# Altium schematic sheet JGL1905_Xyloni_FTDI_V1.06.SchDoc
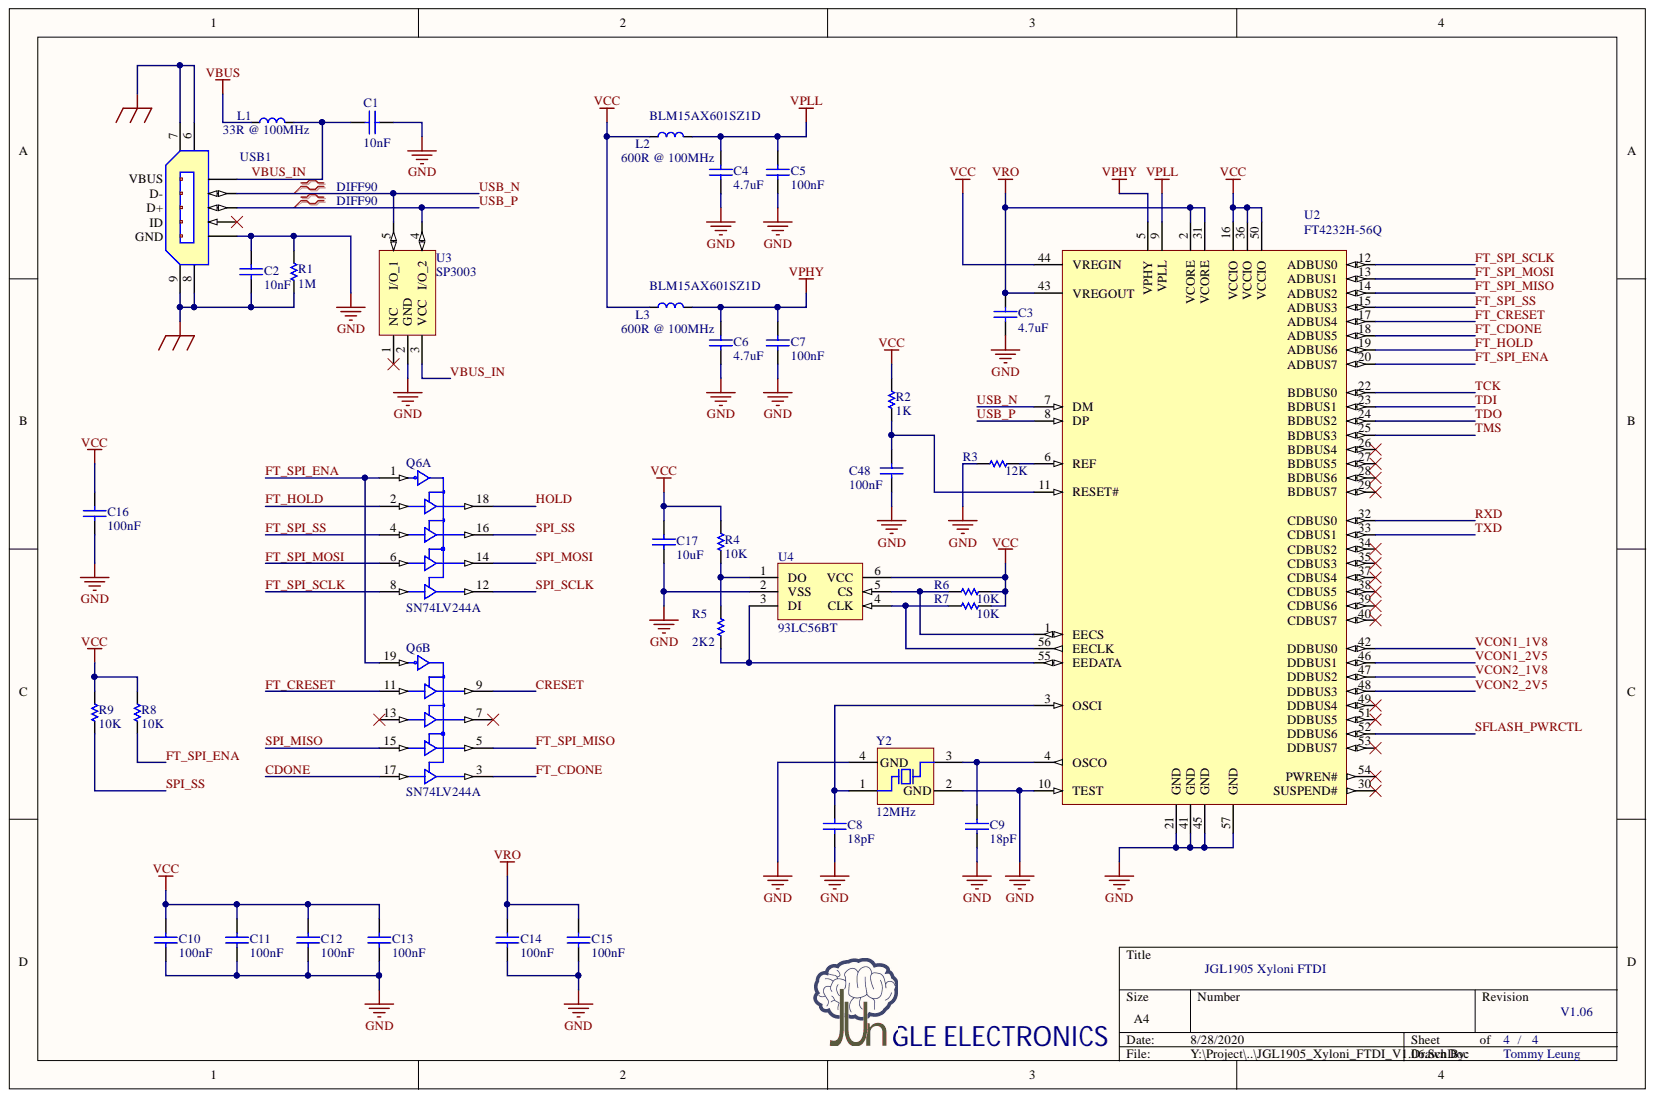
[diagram p0: JGL1905_Xyloni_FTDI_V1.06.SchDoc]

## Parts
C1  value 10nF  [CAPC0402M]  (hidden comment: CAPACITOR)
C2  value 10nF  [CAPC0402M]  (hidden comment: CAPACITOR)
C3  value 4.7uF  [CAPC0402M]  (hidden comment: CAPACITOR)
C4  value 4.7uF  [CAPC0402M]  (hidden comment: CAPACITOR)
C5  value 100nF  [CAPC0402M]  (hidden comment: CAPACITOR)
C6  value 4.7uF  [CAPC0402M]  (hidden comment: CAPACITOR)
C7  value 100nF  [CAPC0402M]  (hidden comment: CAPACITOR)
C8  value 18pF  [CAPC0402M]  (hidden comment: CAPACITOR)
C9  value 18pF  [CAPC0402M]  (hidden comment: CAPACITOR)
C10  value 100nF  [CAPC0402M]  (hidden comment: CAPACITOR)
C11  value 100nF  [CAPC0402M]  (hidden comment: CAPACITOR)
C12  value 100nF  [CAPC0402M]  (hidden comment: CAPACITOR)
C13  value 100nF  [CAPC0402M]  (hidden comment: CAPACITOR)
C14  value 100nF  [CAPC0402M]  (hidden comment: CAPACITOR)
C15  value 100nF  [CAPC0402M]  (hidden comment: CAPACITOR)
C16  value 100nF  [CAPC0402M]  (hidden comment: CAPACITOR)
C17  value 10uF  [CAPC0402M]  (hidden comment: CAPACITOR)
C48  value 100nF  [CAPC0402M]  (hidden comment: CAPACITOR)
L1  value 33R @ 100MHz  [RESC0603M]  (hidden comment: FERRITE_BEADS)
L2  value 600R @ 100MHz  Mfr. PN: BLM15AX601SZ1D  [RESC0402M]  (hidden comment: FERRITE_BEADS)
L3  value 600R @ 100MHz  Mfr. PN: BLM15AX601SZ1D  [RESC0402M]  (hidden comment: FERRITE_BEADS)
Q6  SN74LV244A  [QFN-20-RGY]  (parts A,B)
R1  value 1M  [RESC0402M]  (hidden comment: RESISTOR)
R2  value 1K  [RESC0402M]  (hidden comment: RESISTOR)
R3  value 12K  [RESC0402M]  (hidden comment: RESISTOR)
R4  value 10K  [RESC0402M]  (hidden comment: RESISTOR)
R5  value 2K2  [RESC0402M]  (hidden comment: RESISTOR)
R6  value 10K  [RESC0402M]  (hidden comment: RESISTOR)
R7  value 10K  [RESC0402M]  (hidden comment: RESISTOR)
R8  value 10K  [RESC0402M]  (hidden comment: RESISTOR)
R9  value 10K  [RESC0402M]  (hidden comment: RESISTOR)
U2  FT4232H-56Q  [QFN56_2_N]
U3  SP3003  [SOT-553]
U4  93LC56BT  [SOT-23-6]
USB1  105017-0001  [105017-0001]  (value not drawn)
Y2  value 12MHz  [XTAL-3225]  (hidden comment: XTAL_S3225A)

## Nets
GND: C1.2 C2.1 C3.2 C4.1 C5.1 C6.1 C7.1 C8.2 C9.2 C10.2 C11.2 C12.2 C13.2 C14.2 C15.2 C16.2 C17.2 C48.2 Q6.10 R1.2 R3.1 U2.10(TEST) U2.21(GND) U2.41(GND) U2.45(GND) U2.57(GND) U3.2(GND) U4.2(VSS) USB1.5 Y2.2(GND) Y2.4(GND)
Shield_USB: C2.2 R1.1 USB1.6 USB1.7 USB1.8 USB1.9
VBUS: L1.1
VCC: C10.1 C11.1 C12.1 C13.1 C16.1 C17.1 L2.1 L3.1 Q6.20 R2.2 R4.2 R6.2 R7.2 R8.2 R9.2 U2.16(VCCIO) U2.36(VCCIO) U2.44(VREGIN) U2.50(VCCIO) U4.6(VCC)
VPHY: C6.2 C7.2 L3.2 U2.5(VPHY)
VPLL: C4.2 C5.2 L2.2 U2.9(VPLL)
VRO: C3.1 C14.1 C15.1 U2.2(VCORE) U2.31(VCORE) U2.43(VREGOUT)
CDONE: Q6.17
CRESET: Q6.9
FT_CDONE: Q6.3 U2.18(ADBUS5)
FT_CRESET: Q6.11 U2.17(ADBUS4)
FT_HOLD: Q6.2 U2.19(ADBUS6)
FT_SPI_ENA: Q6.1 Q6.19 R8.1 U2.20(ADBUS7)
FT_SPI_MISO: Q6.5 U2.14(ADBUS2)
FT_SPI_MOSI: Q6.6 U2.13(ADBUS1)
FT_SPI_SCLK: Q6.8 U2.12(ADBUS0)
FT_SPI_SS: Q6.4 U2.15(ADBUS3)
HOLD: Q6.18
RXD: U2.32(CDBUS0)
SFLASH_PWRCTL: U2.52(DDBUS6)
SPI_MISO: Q6.15
SPI_MOSI: Q6.14
SPI_SCLK: Q6.12
SPI_SS: Q6.16 R9.1
TCK: U2.22(BDBUS0)
TDI: U2.23(BDBUS1)
TDO: U2.24(BDBUS2)
TMS: U2.25(BDBUS3)
TXD: U2.33(CDBUS1)
USB_N: U2.7(DM) U3.5(I/O_1) USB1.2
USB_P: U2.8(DP) U3.4(I/O_2) USB1.3
VBUS_IN: C1.1 L1.2 U3.3(VCC) USB1.1
VCON1_1V8: U2.42(DDBUS0)
VCON1_2V5: U2.46(DDBUS1)
VCON2_1V8: U2.47(DDBUS2)
VCON2_2V5: U2.48(DDBUS3)
NetC8_1: C8.1 U2.3(OSCI) Y2.1
NetC9_1: C9.1 U2.4(OSCO) Y2.3
NetC48_1: C48.1 R2.1 U2.11(RESET#)
NetR3_2: R3.2 U2.6(REF)
NetR4_1: R4.1 R5.2 U4.1(DO)
NetR5_1: R5.1 U2.55(EEDATA) U4.3(DI)
NetR6_1: R6.1 U2.1(EECS) U4.5(CS)
NetR7_1: R7.1 U2.56(EECLK) U4.4(CLK)

Not connected: Q6 7 13; U2 26(BDBUS4) 27(BDBUS5) 28(BDBUS6) 29(BDBUS7) 30(SUSPEND#) 34(CDBUS2) 35(CDBUS3) 37(CDBUS4) 38(CDBUS5) 39(CDBUS6) 40(CDBUS7) 49(DDBUS4) 51(DDBUS5) 53(DDBUS7) 54(PWREN#); U3 1(NC); USB1 4

## Library data of the parts
C1 C2 = CAPACITOR: Mfr. PN: GCM155R71H103KA5J; Component Kind: Standard; Description: Capacitor; Supplier 1: Mouser; Supplier Part Number 1: 81-GCM155R71H103KA5J; Library Name: Jungle_ADLibrary.IntLib
C3 C4 C6 = CAPACITOR: Mfr. PN: GRM155R60J475ME7J; Component Kind: Standard; Description: Capacitor; Supplier 1: Mouser; Supplier Part Number 1: 81-GRM155R60J475ME7J; Library Name: Jungle_ADLibrary.IntLib
C5 C7 C10-C16 C48 = CAPACITOR: Mfr. PN: EMK105B7104KV-F; Component Kind: Standard; Description: Capacitor; Supplier 1: Mouser; Supplier Part Number 1: 963-EMK105B7104KV-F; Library Name: Jungle_ADLibrary.IntLib
C8 C9 = CAPACITOR: Mfr. PN: GCM1555C1H180JA6D; Component Kind: Standard; Description: Capacitor; Supplier 1: Mouser; Supplier Part Number 1: 81-GCM1555C1H180JA6D; Library Name: Jungle_ADLibrary.IntLib
C17 = CAPACITOR: Mfr. PN: GRM155C80J106ME1J; Component Kind: Standard; Description: Capacitor; Supplier 1: Mouser; Supplier Part Number 1: 81-GRM155C80J106ME1J; Library Name: Jungle_ADLibrary.IntLib
L1 = FERRITE_BEADS: Ferrite Beads; Mfr. PN: BK1608HS330-T; Component Kind: Standard; Supplier 1: Mouser; Supplier Part Number 1: 963-BK1608HS330-T; Library Name: Jungle_ADLibrary.IntLib
L2 L3 = FERRITE_BEADS: Ferrite Beads; Value: 600R @ 100MHz; Component Kind: Standard; Supplier 1: Mouser; Supplier Part Number 1: 81-BLM15AX601SZ1D; Library Name: Jungle_ADLibrary.IntLib
Q6 = SN74LV244A: Octal Buffers and Drivers With 3-State Outputs; Mfr. PN: SN74LV244ARGYR; Component Kind: Standard; Supplier 1: Mouser; Supplier Part Number 1: 595-SN74LV244ARGYR; Library Name: Jungle_ADLibrary.IntLib
R1 = RESISTOR: Mfr. PN: RC0402FR-071ML; Component Kind: Standard; Description: Resistor; Supplier 1: Mouser; Supplier Part Number 1: 603-RC0402FR-071ML; Library Name: Jungle_ADLibrary.IntLib
R2 = RESISTOR: Mfr. PN: RC0402FR-071KL; Component Kind: Standard; Description: Resistor; Supplier 1: Mouser; Supplier Part Number 1: 603-RC0402FR-071KL; Library Name: Jungle_ADLibrary.IntLib
R3 = RESISTOR: Mfr. PN: RC0402FR-0712KL; Component Kind: Standard; Description: Resistor; Supplier 1: Mouser; Supplier Part Number 1: 603-RC0402FR-0712KL; Library Name: Jungle_ADLibrary.IntLib
R4 R6-R9 = RESISTOR: Mfr. PN: RC0402FR-0710KL; Component Kind: Standard; Description: Resistor; Supplier 1: Mouser; Supplier Part Number 1: 603-RC0402FR-0710KL; Library Name: Jungle_ADLibrary.IntLib
R5 = RESISTOR: Mfr. PN: RC0402FR-072K2L; Component Kind: Standard; Description: Resistor; Supplier 1: Mouser; Supplier Part Number 1: 603-RC0402FR-072K2L; Library Name: Jungle_ADLibrary.IntLib
U2 = FT4232H-56Q: Quad High Speed USB To Multipurpose UART/MPSSE IC, 56-pin QFN; Mfr. PN: FT4232H-56Q-REEL; Component Kind: Standard; Supplier 1: Mouser; Supplier Part Number 1: 895-FT4232H-56Q-REEL; Library Name: Jungle_ADLibrary.IntLib
U3 = SP3003: TVS Diode Arrays, HDMI, DVI, USB2.0, IEEE 1394 Application; Mfr. PN: SP3003-02XTG; Component Kind: Standard; Supplier 1: Mouser; Supplier Part Number 1: 576-SP3003-02XTG; Value: SP3003; Library Name: Jungle_ADLibrary.IntLib
U4 = 93LC56BT_OT: 2K Microwire Compatible Serial EEPROM; Component Kind: Standard; Mfr. PN: 93LC56BT; Supplier 1: Mouser; Supplier Part Number 1: 579-93LC56BT-I/OT; Library Name: Jungle_ADLibrary.IntLib
USB1 = USB_CON_MICRO: Micro-USB B Receptacle, Bottom Mount, Surface Mount; Component Kind: Standard; Mfr. PN: 105017-0001; Supplier 1: Mouser; Supplier Part Number 1: 538-105017-0001; Library Name: Jungle_ADLibrary.IntLib
Y2 = XTAL_S3225A: Quantz Crystal, S3225A, 12M, -+20ppm, -+30ppm(-40~+85), 18pF, 100ohms; Mfr. PN: ABM8G-12.000MHZ-18-D2Y-T; Component Kind: Standard; Supplier 1: Mouser; Supplier Part Number 1: 815-ABM8G-12-18D2Y; Library Name: Jungle_ADLibrary.IntLib
